# Altium schematic sheet Sheet2.SchDoc
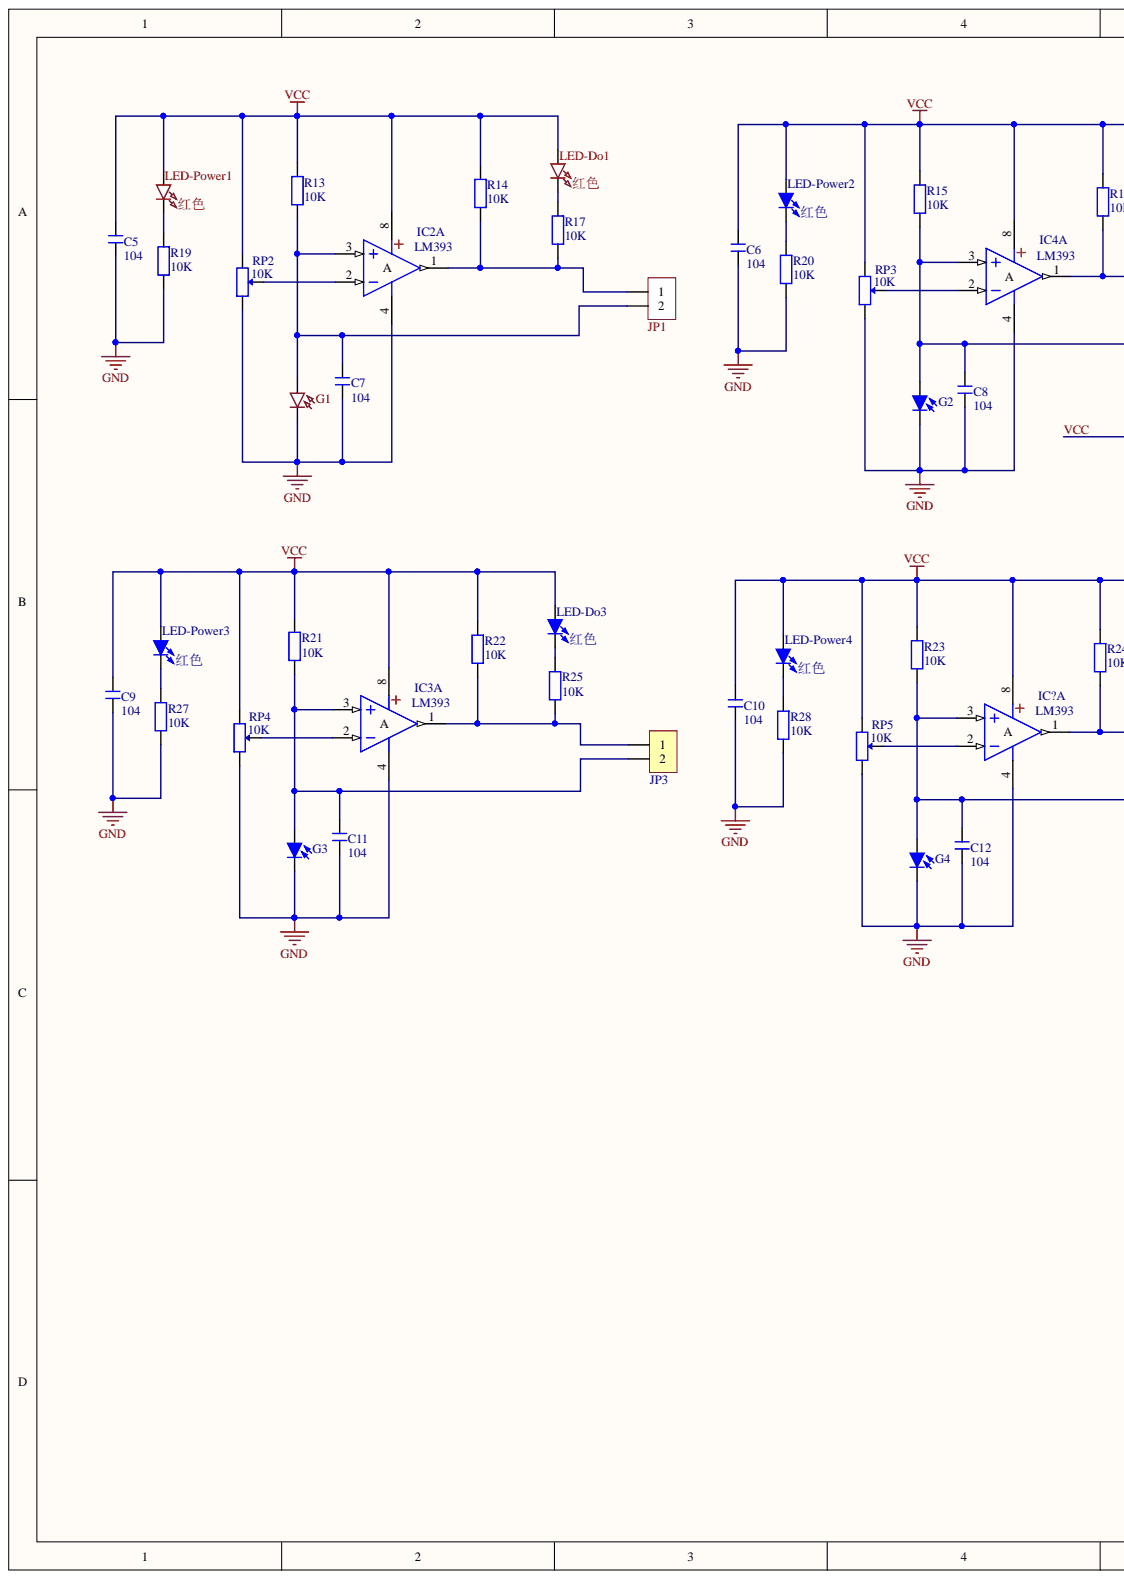
[diagram p0: Sheet2.SchDoc, left]
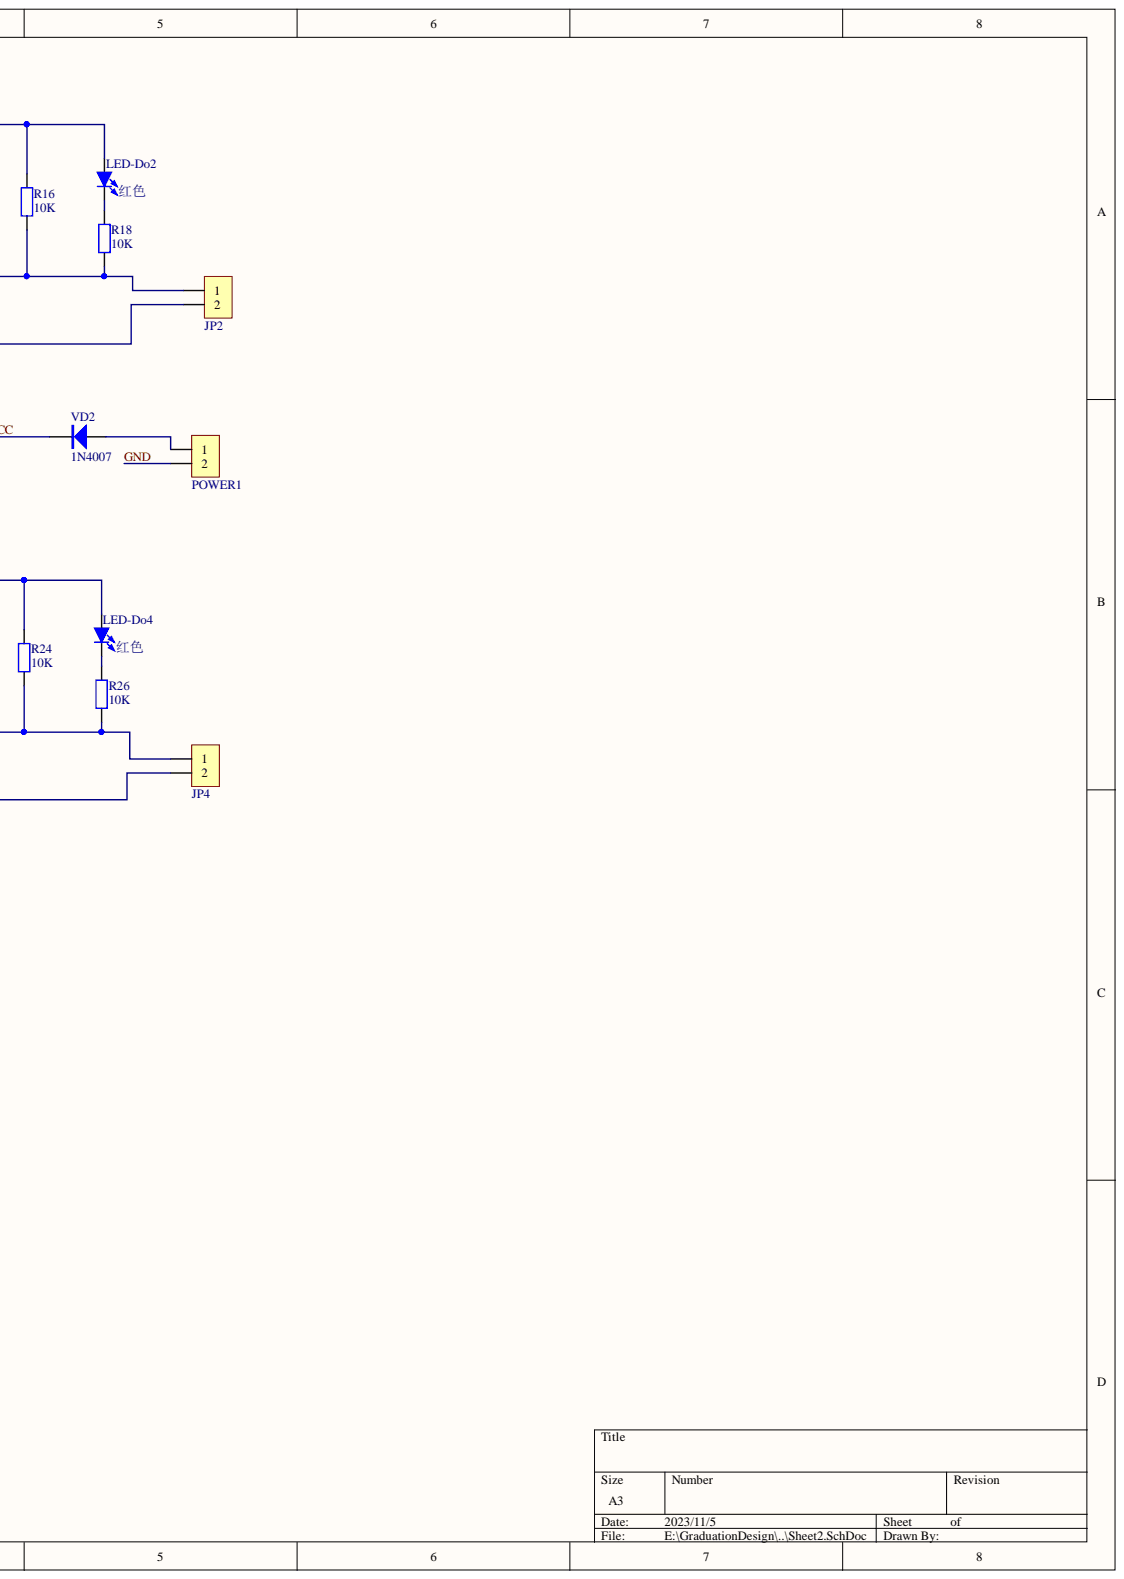
[diagram p1: Sheet2.SchDoc, right]

## Parts
C5  104  [CC 1.8*3.6]
C6  104  [CC 1.8*3.6]
C7  104  [CC 1.8*3.6]
C8  104  [CC 1.8*3.6]
C9  104  [CC 1.8*3.6]
C10  104  [CC 1.8*3.6]
C11  104  [CC 1.8*3.6]
C12  104  [CC 1.8*3.6]
G1  guangMinErJiGuan  [guanMinErJiGuan]  (value not drawn)
G2  guangMinErJiGuan  [guanMinErJiGuan]  (value not drawn)
G3  guangMinErJiGuan  [guanMinErJiGuan]  (value not drawn)
G4  guangMinErJiGuan  [guanMinErJiGuan]  (value not drawn)
IC2  LM393  [SOP8_L]  (part A)
IC3  LM393  [SOP8_L]  (part A)
IC4  LM393  [SOP8_L]  (part A)
IC?  LM393  [SOP8_L]  (part A)
JP1  HDR-1X2  [HDR-1X2]  (value not drawn)
JP2  HDR-1X2  [HDR-1X2]  (value not drawn)
JP3  HDR-1X2  [HDR-1X2]  (value not drawn)
JP4  HDR-1X2  [HDR-1X2]  (value not drawn)
LED-Do1  红色  [LED 3MM-B]
LED-Do2  红色  [LED 3MM-B]
LED-Do3  红色  [LED 3MM-B]
LED-Do4  红色  [LED 3MM-B]
LED-Power1  红色  [LED 3MM-B]
LED-Power2  红色  [LED 3MM-B]
LED-Power3  红色  [LED 3MM-B]
LED-Power4  红色  [LED 3MM-B]
POWER1  HDR-1X2  [HDR-1X2]  (value not drawn)
R13  10K  [RS 1/4W]
R14  10K  [RS 1/4W]
R15  10K  [RS 1/4W]
R16  10K  [RS 1/4W]
R17  10K  [RS 1/4W]
R18  10K  [RS 1/4W]
R19  10K  [RS 1/4W]
R20  10K  [RS 1/4W]
R21  10K  [RS 1/4W]
R22  10K  [RS 1/4W]
R23  10K  [RS 1/4W]
R24  10K  [RS 1/4W]
R25  10K  [RS 1/4W]
R26  10K  [RS 1/4W]
R27  10K  [RS 1/4W]
R28  10K  [RS 1/4W]
RP2  10K  [3296W]
RP3  10K  [3296W]
RP4  10K  [3296W]
RP5  10K  [3296W]
VD2  1N4007  [DO-41]

## Nets
GND: C5.2 C6.2 C7.2 C8.2 C9.2 C10.2 C11.2 C12.2 G1.2 G2.2 G3.2 G4.2 IC2.4(A) IC3.4(A) IC4.4(A) IC?.4(A) POWER1.2 R19.1 R20.1 R27.1 R28.1 RP2.3 RP3.3 RP4.3 RP5.3
VCC: C5.1 C6.1 C9.1 C10.1 IC2.8 IC3.8 IC4.8 IC?.8 LED-Do1.1 LED-Do2.1 LED-Do3.1 LED-Do4.1 LED-Power1.1 LED-Power2.1 LED-Power3.1 LED-Power4.1 R13.2 R14.2 R15.2 R16.2 R21.2 R22.2 R23.2 R24.2 RP2.1 RP3.1 RP4.1 RP5.1 VD2.2
NetC7_1: C7.1 G1.1 IC2.3 JP1.2 R13.1
NetC8_1: C8.1 G2.1 IC4.3 JP2.2 R15.1
NetC11_1: C11.1 G3.1 IC3.3 JP3.2 R21.1
NetC12_1: C12.1 G4.1 IC?.3 JP4.2 R23.1
NetIC2_1: IC2.1 JP1.1 R14.1 R17.1
NetIC2_2: IC2.2 RP2.2
NetIC3_1: IC3.1 JP3.1 R22.1 R25.1
NetIC3_2: IC3.2 RP4.2
NetIC4_1: IC4.1 JP2.1 R16.1 R18.1
NetIC4_2: IC4.2 RP3.2
NetIC?_1: IC?.1 JP4.1 R24.1 R26.1
NetIC?_2: IC?.2 RP5.2
NetLED-Do1_2: LED-Do1.2 R17.2
NetLED-Do2_2: LED-Do2.2 R18.2
NetLED-Do3_2: LED-Do3.2 R25.2
NetLED-Do4_2: LED-Do4.2 R26.2
NetLED-Power1_2: LED-Power1.2 R19.2
NetLED-Power2_2: LED-Power2.2 R20.2
NetLED-Power3_2: LED-Power3.2 R27.2
NetLED-Power4_2: LED-Power4.2 R28.2
NetPOWER1_1: POWER1.1 VD2.1

## Library data of the parts
C5-C12 = C-CC: 直插瓷片电容; 版权归属: 源创客; BOM名称: 直插瓷片电容; Component Kind: Standard; 学习资源: www.ooocke.com; Library Name: 电阻-电容-电感 - 副本.SchLib
IC2-IC4 IC? = LM393: 双路电压比较; 版权归属: 源创客; BOM名称: 双路电压比较; Component Kind: Standard; Library Name: 1.1   - IC芯片.SchLib; 学习资源: www.ooocke.com
JP1-JP4 POWER1 = Header 2: 2P接插件; 版权归属: 源创客; BOM名称: 2P接插件; Component Kind: Standard; 学习资源: www.ooocke.com; Library Name: 接插件 - 副本.SchLib
LED-Do1-LED-Do4 LED-Power1-LED-Power4 = LED-3MM: 插件LED; 版权归属: 源创客; BOM名称: 插件LED; Component Kind: Standard; 学习资源: www.ooocke.com; Library Name: LED - 副本.SchLib
R13-R28 = R-CRR: 直插色环电阻; BOM名称: 色环电阻; 版权归属: 源创客; Component Kind: Standard; Library Name: 3.1   - 电阻-电容-电感.SchLib; 学习资源: www.ooocke.com
RP2-RP5 = RP-ID: 插件单联电位器; BOM名称: 插件电位器; 版权归属: 源创客; Component Kind: Standard; Library Name: 3.1   - 电阻-电容-电感.SchLib; 学习资源: www.ooocke.com
VD2 = 1N4007: 整流二极管; 版权归属: 源创客; BOM名称: 整流二极管; Component Kind: Standard; 学习资源: www.ooocke.com; Library Name: 二极管-整流桥 - 副本.SchLib
G1-G4 = guangMinErJiGuan: Component Kind: Standard; Library Name: Schlib1.SchLib
